# Altium schematic sheet TSAL_Circuits.SchDoc(TSAL_Circuits)
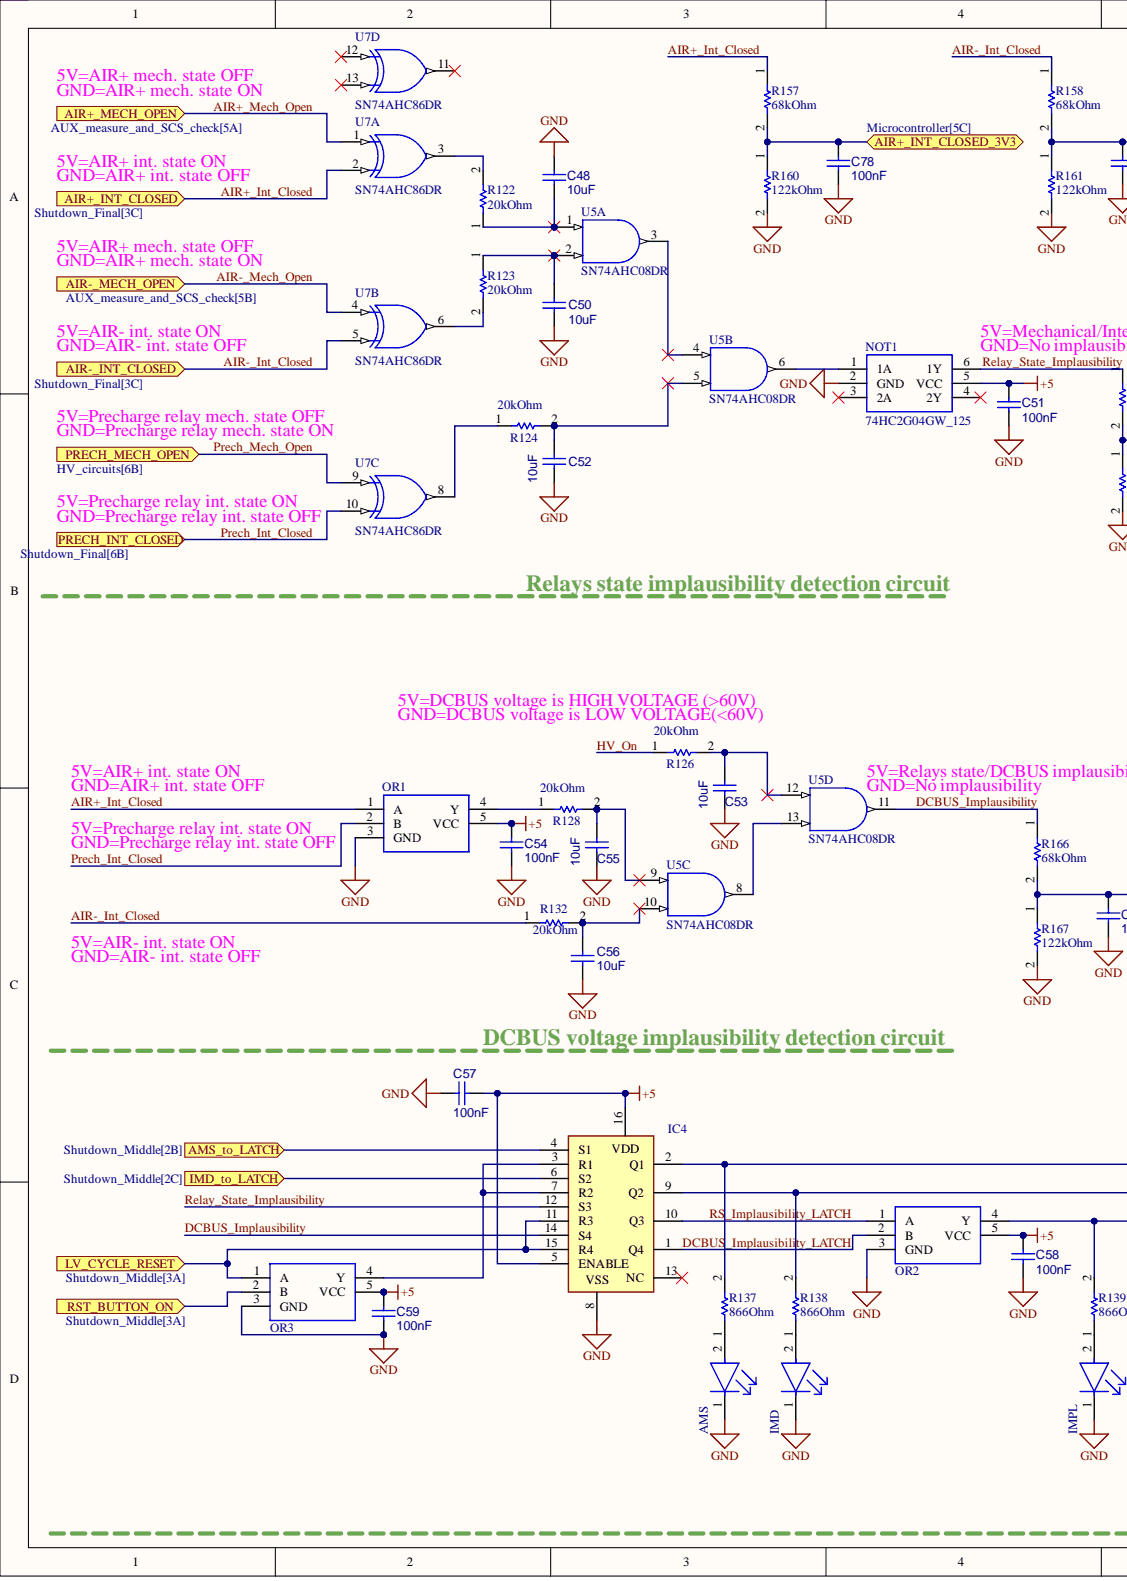
[diagram p0: TSAL_Circuits.SchDoc, left]
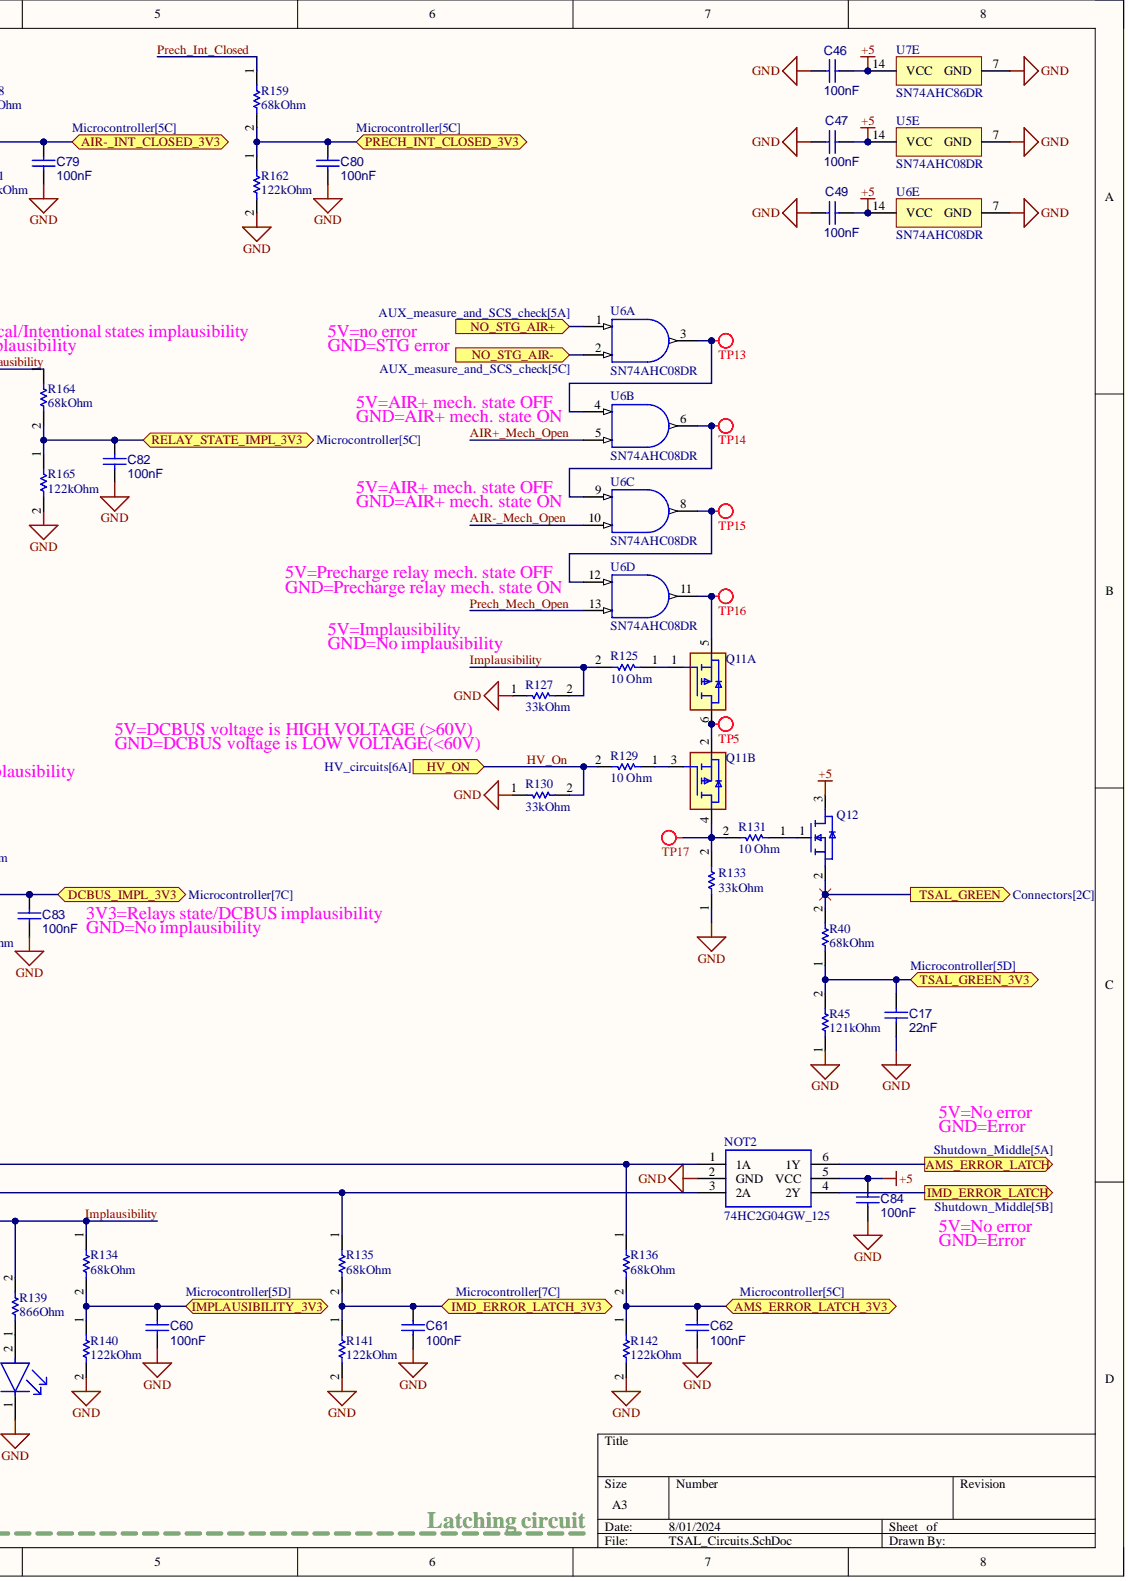
[diagram p1: TSAL_Circuits.SchDoc, right]

## Parts
AMS  RED_LED  (value not drawn)
C17  22nF
C46  100nF
C47  100nF
C48  10uF
C49  100nF
C50  10uF
C51  100nF
C52  10uF
C53  10uF
C54  100nF
C55  10uF
C56  10uF
C57  100nF
C58  100nF
C59  100nF
C60  100nF
C61  100nF
C62  100nF
C78  100nF
C79  100nF
C80  100nF
C82  100nF
C83  100nF
C84  100nF
IC4  CD4043BNSR  (value not drawn)
IMD  RED_LED  (value not drawn)
IMPL  LED_RED  (value not drawn)
NOT1  74HC2G04GW_125
NOT2  74HC2G04GW_125
OR1  1 gate 2 Input OR  (value not drawn)
OR2  1 gate 2 Input OR  (value not drawn)
OR3  1 gate 2 Input OR  (value not drawn)
Q11  SI3993CDV-T1-GE3  (value not drawn)  (parts A,B)
Q12  SI2304BDS-T1-E3  (value not drawn)
R40  68kOhm
R45  121kOhm
R122  20kOhm
R123  20kOhm
R124  20kOhm
R125  10 Ohm
R126  20kOhm
R127  33kOhm
R128  20kOhm
R129  10 Ohm
R130  33kOhm
R131  10 Ohm
R132  20kOhm
R133  33kOhm
R134  68kOhm
R135  68kOhm
R136  68kOhm
R137  866Ohm
R138  866Ohm
R139  866Ohm
R140  122kOhm
R141  122kOhm
R142  122kOhm
R157  68kOhm
R158  68kOhm
R159  68kOhm
R160  122kOhm
R161  122kOhm
R162  122kOhm
R164  68kOhm
R165  122kOhm
R166  68kOhm
R167  122kOhm
TP5  Test Point  (value not drawn)
TP13  Test Point  (value not drawn)
TP14  Test Point  (value not drawn)
TP15  Test Point  (value not drawn)
TP16  Test Point  (value not drawn)
TP17  Test Point  (value not drawn)
U5  SN74AHC08DR  (parts A,B,C,D,E)
U6  SN74AHC08DR  (parts A,B,C,D,E)
U7  SN74AHC86DR  (parts A,B,C,D,E)

## Ports
AIR+_INT_CLOSED, AIR+_INT_CLOSED_3V3, AIR+_MECH_OPEN, AIR-_INT_CLOSED, AIR-_INT_CLOSED_3V3, AIR-_MECH_OPEN, AMS_ERROR_LATCH, AMS_ERROR_LATCH_3V3, AMS_to_LATCH, DCBUS_IMPL_3V3, HV_ON, IMD_ERROR_LATCH, IMD_ERROR_LATCH_3V3, IMD_to_LATCH, IMPLAUSIBILITY_3V3, LV_CYCLE_RESET, NO_STG_AIR+, NO_STG_AIR-, PRECH_INT_CLOSED, PRECH_INT_CLOSED_3V3, PRECH_MECH_OPEN, RELAY_STATE_IMPL_3V3, RST_BUTTON_ON, TSAL_GREEN, TSAL_GREEN_3V3

## Nets
+5: C46.2 C47.2 C49.2 C51.2 C54.2 C57.2 C58.2 C59.2 C84.2 IC4.5(ENABLE) IC4.16 NOT1.5(VCC) NOT2.5(VCC) OR1.5(VCC) OR2.5(VCC) OR3.5(VCC) Q12.3 U5.14(VCC) U6.14(VCC) U7.14(VCC)
GND: AMS.1 C17.1 C46.1 C47.1 C48.1 C49.1 C50.1 C51.1 C52.1 C53.1 C54.1 C55.1 C56.1 C57.1 C58.1 C59.1 C60.2 C61.2 C62.2 C78.2 C79.2 C80.2 C82.2 C83.2 C84.1 IC4.8 IMD.1 IMPL.1 NOT1.2(GND) NOT2.2(GND) OR1.3(GND) OR2.3(GND) OR3.3(GND) R45.1 R127.1 R130.1 R133.1 R140.2 R141.2 R142.2 R160.2 R161.2 R162.2 R165.2 R167.2 U5.7(GND) U6.7(GND) U7.7(GND)
AIR+_Int_Closed: OR1.1(A) R157.1 U7.2 ; port AIR+_INT_CLOSED
AIR+_Mech_Open: U6.5 U7.1 ; port AIR+_MECH_OPEN
AIR-_Int_Closed: R132.1 R158.1 U7.5 ; port AIR-_INT_CLOSED
AIR-_Mech_Open: U6.10 U7.4 ; port AIR-_MECH_OPEN
AMS_ERROR_LATCH: NOT2.6(1Y) ; port AMS_ERROR_LATCH
AMS_ERROR_LATCH_3V3: C62.1 R136.2 R142.1 ; port AMS_ERROR_LATCH_3V3
AMS_to_LATCH: IC4.4(S1) ; port AMS_to_LATCH
DCBUS_IMPL_3V3: C83.1 R166.2 R167.1 ; port DCBUS_IMPL_3V3
DCBUS_Implausibility: IC4.14(S4) R166.1 U5.11
DCBUS_Implausibility_LATCH: IC4.1(Q4) OR2.2(B)
HV_On: R126.1 R129.2 R130.2 ; port HV_ON
IMD_ERROR_LATCH: NOT2.4(2Y) ; port IMD_ERROR_LATCH
IMD_ERROR_LATCH_3V3: C61.1 R135.2 R141.1 ; port IMD_ERROR_LATCH_3V3
IMD_to_LATCH: IC4.6(S2) ; port IMD_to_LATCH
Implausibility: OR2.4(Y) R125.2 R127.2 R134.1 R139.2
IMPLAUSIBILITY_3V3: C60.1 R134.2 R140.1 ; port IMPLAUSIBILITY_3V3
LV_CYCLE_RESET: IC4.11(R3) IC4.15(R4) OR3.1(A) ; port LV_CYCLE_RESET
Prech_Int_Closed: OR1.2(B) R159.1 U7.10 ; port PRECH_INT_CLOSED
PRECH_INT_CLOSED_3V3: C80.1 R159.2 R162.1 ; port PRECH_INT_CLOSED_3V3
Prech_Mech_Open: U6.13 U7.9 ; port PRECH_MECH_OPEN
RELAY_STATE_IMPL_3V3: C82.1 R164.2 R165.1 ; port RELAY_STATE_IMPL_3V3
Relay_State_Implausibility: IC4.12(S3) NOT1.6(1Y) R164.1
RS_Implausibility_LATCH: IC4.10(Q3) OR2.1(A)
RST_BUTTON_ON: OR3.2(B) ; port RST_BUTTON_ON
TSAL_GREEN: Q12.2 R40.2 ; port TSAL_GREEN
TSAL_GREEN_3V3: C17.2 R40.1 R45.2 ; port TSAL_GREEN_3V3
NetAMS_2: AMS.2 R137.1
NetC48_2: C48.2 R122.1 U5.1
NetC50_2: C50.2 R123.1 U5.2
NetC52_2: C52.2 R124.2 U5.5
NetC53_2: C53.2 R126.2 U5.12
NetC55_2: C55.2 R128.2 U5.9
NetC56_2: C56.2 R132.2 U5.10
NetC78_1: C78.1 R157.2 R160.1
NetC79_1: C79.1 R158.2 R161.1
NetIC4_2: IC4.2(Q1) NOT2.1(1A) R136.1 R137.2
NetIC4_3: IC4.3(R1) IC4.7(R2) OR3.4(Y)
NetIC4_9: IC4.9(Q2) NOT2.3(2A) R135.1 R138.2
NetIMD_2: IMD.2 R138.1
NetIMPL_2: IMPL.2 R139.1
NetNOT1_1: NOT1.1(1A) U5.6
NetOR1_4: OR1.4(Y) R128.1
NetQ11_1: Q11.1(G1) R125.1
NetQ11_2: Q11.2 Q11.6(D1) TP5.1
NetQ11_3: Q11.3 R129.1
NetQ11_4: Q11.4 R131.2 R133.2 TP17.1
NetQ11_5: Q11.5(S1) TP16.1 U6.11
NetQ12_1: Q12.1 R131.1
NetR122_2: R122.2 U7.3
NetR123_2: R123.2 U7.6
NetR124_1: R124.1 U7.8
NetTP13_1: TP13.1 U6.3 U6.4
NetTP14_1: TP14.1 U6.6 U6.9
NetTP15_1: TP15.1 U6.8 U6.12
NetU5_3: U5.3 U5.4
NetU5_8: U5.8 U5.13
NetU6_1: U6.1
NetU6_2: U6.2

Not connected: IC4 13(NC); NOT1 3(2A) 4(2Y); U7 11 12 13

## Library data of the parts
AMS IMD IMPL = CMP-007-00036-2: VLMS1300-GS08; Tech: SMD; Component Kind: Standard; Package: 0603; Library Name: EMEA- Squadra Corse PoliTO-  Politecnico di Torino
C17 = CMP-001-00085-5: VJ0805Y223JXACW1BC; Tech: SMD; Component Kind: Standard; ComponentLink1Description: Website; Package: 0805; Library Name: EMEA- Squadra Corse PoliTO-  Politecnico di Torino
C46 C47 C49 C51 C54 C57-C62 C78-C80 C82-C84 = CMP-001-00085-5: VJ0805Y104MXATW1BC; Tech: SMD; Component Kind: Standard; ComponentLink1Description: Website; Package: 0805; Library Name: EMEA- Squadra Corse PoliTO-  Politecnico di Torino
C48 C50 C52 C53 C55 C56 = CMP-001-00085-5: GRM21BR71A106KA73K; Tech: SMD; Component Kind: Standard; ComponentLink1Description: Website; Package: 0805; Library Name: EMEA- Squadra Corse PoliTO-  Politecnico di Torino
IC4 = CMP-030-000042-2: CMOS Quad NOR R/S Latch with 3-State Outputs; Manufacturer_Name: Texas Instruments; Arrow Part Number: CD4043BNSR; Arrow Price/Stock: https://www.arrow.com/en/products/cd4043bnsr/texas-instruments?region=nac; Component Kind: Standard; Datasheet Link: http://www.ti.com/lit/gpn/cd4043b; Design Item ID: CD4043BNSR; Height: 2mm; Manufacturer_Part_Number: CD4043BNSR; Mouser Part Number: 595-CD4043BNSR; Mouser Price/Stock: https://www.mouser.co.uk/ProductDetail/Texas-Instruments/CD4043BNSR?qs=dQbkqP7qjaak3gfhrS2sTg%3D%3D; Source: SamacSys.SchLib; Library Name: EMEA- Squadra Corse PoliTO-  Politecnico di Torino
NOT1 NOT2 = CMP-071-000000-3: 74HC(T)2G04 - Dual inverter@en-us; Tech: SMD; Component Kind: Standard; Package: TSSOP-6; Library Name: EMEA- Squadra Corse PoliTO-  Politecnico di Torino
OR1-OR3 = CMP-002-000003-1: SN74AHC1G32DBVT; Manufacturer_Name: Texas Instruments; Geometry.Height: 1.45mm; Component Kind: Standard; ComponentLink1Description: Website; ComponentLink1URL: https://www.mouser.it/ProductDetail/Texas-Instruments/SN74AHC1G32DBVT?qs=fSCvMSq5ISVX4j%2FpWgAWfA%3D%3D; Datasheet Link: http://www.ti.com/general/docs/lit/getliterature.tsp?genericPartNumber=sn74ahc1g32&fileType=pdf; Design Item ID: SN74AHC1G32DBVT; Manufacturer_Part_Number: SN74AHC1G32DBVT; Mouser Part Number: 595-SN74AHC1G32DBVT; Mouser Price/Stock: https://www.mouser.co.uk/ProductDetail/Texas-Instruments/SN74AHC1G32DBVT?qs=fSCvMSq5ISVX4j%2FpWgAWfA%3D%3D; Source: SN74AHC1G32DBVT.SchLib; Library Name: EMEA- Squadra Corse PoliTO-  Politecnico di Torino
Q11 = CMP-032-000017-2: Dual P-Channel MOSFET; Manufacturer_Name: Vishay; Component Kind: Standard; Datasheet Link: https://www.vishay.com/docs/67331/si3993cdv.pdf; Design Item ID: SI3993CDV-T1-GE3; Height: 1.1mm; Manufacturer_Part_Number: SI3993CDV-T1-GE3; Source: SamacSys.SchLib; Library Name: EMEA- Squadra Corse PoliTO-  Politecnico di Torino
Q12 = CMP-030-000046-3: Manufacturer_Name: Vishay; Arrow Part Number: SI2304BDS-T1-E3; Arrow Price/Stock: https://www.arrow.com/en/products/si2304bds-t1-e3/vishay?region=nac; Component Kind: Standard; Datasheet Link: http://www.vishay.com/docs/72503/si2304bds.pdf; Description: SI2304BDS-T1-E3; Design Item ID: SI2304BDS-T1-E3; Height: 1.12mm; Manufacturer_Part_Number: SI2304BDS-T1-E3; Mouser Part Number: 781-SI2304BDS-T1-E3; Mouser Price/Stock: https://www.mouser.co.uk/ProductDetail/Vishay-Semiconductors/SI2304BDS-T1-E3?qs=jcx%252B0HVgj2YWUCT3FuZcRA%3D%3D; Source: SamacSys.SchLib; Library Name: EMEA- Squadra Corse PoliTO-  Politecnico di Torino
R40 R134-R136 R157-R159 R164 R166 = CMP-009-00179-5: CRCW060368K0FKEAC; Tech: SMD; Component Kind: Standard; ComponentLink1Description: Website; Package: 0603; Library Name: EMEA- Squadra Corse PoliTO-  Politecnico di Torino
R45 R140-R142 R160-R162 R165 R167 = CMP-009-00179-5: CRCW0603121KFKEA; Tech: SMD; Component Kind: Standard; ComponentLink1Description: Website; Package: 0603; Library Name: EMEA- Squadra Corse PoliTO-  Politecnico di Torino
R122-R124 R126 R128 R132 = CMP-009-00179-5: CRCW060320K0FKEAC; Tech: SMD; Component Kind: Standard; ComponentLink1Description: Website; Package: 0603; Library Name: EMEA- Squadra Corse PoliTO-  Politecnico di Torino
R125 R129 R131 = CMP-009-00179-5: CRCW060310R0FKEAHP; Tech: SMD; Component Kind: Standard; ComponentLink1Description: Website; Package: 0603; Library Name: EMEA- Squadra Corse PoliTO-  Politecnico di Torino
R127 R130 R133 = CMP-009-00179-5: CRCW060333K0FKEAC; Tech: SMD; Component Kind: Standard; ComponentLink1Description: Website; Package: 0603; Library Name: EMEA- Squadra Corse PoliTO-  Politecnico di Torino
R137-R139 = CMP-009-00179-5: CRCW0603866RFKEA; Tech: SMD; Component Kind: Standard; ComponentLink1Description: Website; Package: 0603; Library Name: EMEA- Squadra Corse PoliTO-  Politecnico di Torino
TP5 TP13-TP17 = CMP-002-00071-2: Component Kind: Standard; Description: Test Point; Library Name: EMEA- Squadra Corse PoliTO-  Politecnico di Torino
U5 U6 = CMP-0777-00049-3: Quadruple 2-Input Positive-AND Gates, D0014A, LARGE T&R; Manufacturer: Texas Instruments; Bits(#): 4; F @ Nom Voltage(Max)(Mhz): 110; ICC @ Nom Voltage(Max)(mA): 0.02; Input Type: CMOS; Output Drive (IOL/IOH)(Max)(mA): -50/50; Rating: Catalog; Schmitt Trigger: No; Technology Family: AHC; tpd @ Nom Voltage(Max)(ns): 14,9; VCC(Max)(V): 5.5; VCC(Min)(V): 2; Voltage(Nom)(V): 3.3,5; Component Kind: Standard; DatasheetVersion: SCLS236I; Generic Part Number: SN74AHC08; Library Name: Altium Content Vault; Operating Temperature Range(C): -40 to 125; Output Type: CMOS; PackageReference: D0014A
U7 = CMP-0778-00014-3: Quadruple 2-Input Exclusive-OR Gates, D0014A, LARGE T&R; Manufacturer: Texas Instruments; Bits(#): 4; F @ Nom Voltage(Max)(Mhz): 110; ICC @ Nom Voltage(Max)(mA): 0.02; Input Type: CMOS; Output Drive (IOL/IOH)(Max)(mA): 50/-50; Rating: Catalog; Schmitt Trigger: No; Technology Family: AHC; tpd @ Nom Voltage(Max)(ns): 16.5,10; VCC(Max)(V): 5.5; VCC(Min)(V): 2; Voltage(Nom)(V): 3.3,5; Component Kind: Standard; DatasheetVersion: SCLS249J; Generic Part Number: SN74AHC86; Library Name: Altium Content Vault; Operating Temperature Range(C): -40 to 125; Output Type: CMOS; PackageReference: D0014A
